# Altium schematic sheet ga-rele-c.SchDoc(ga-rele-c)
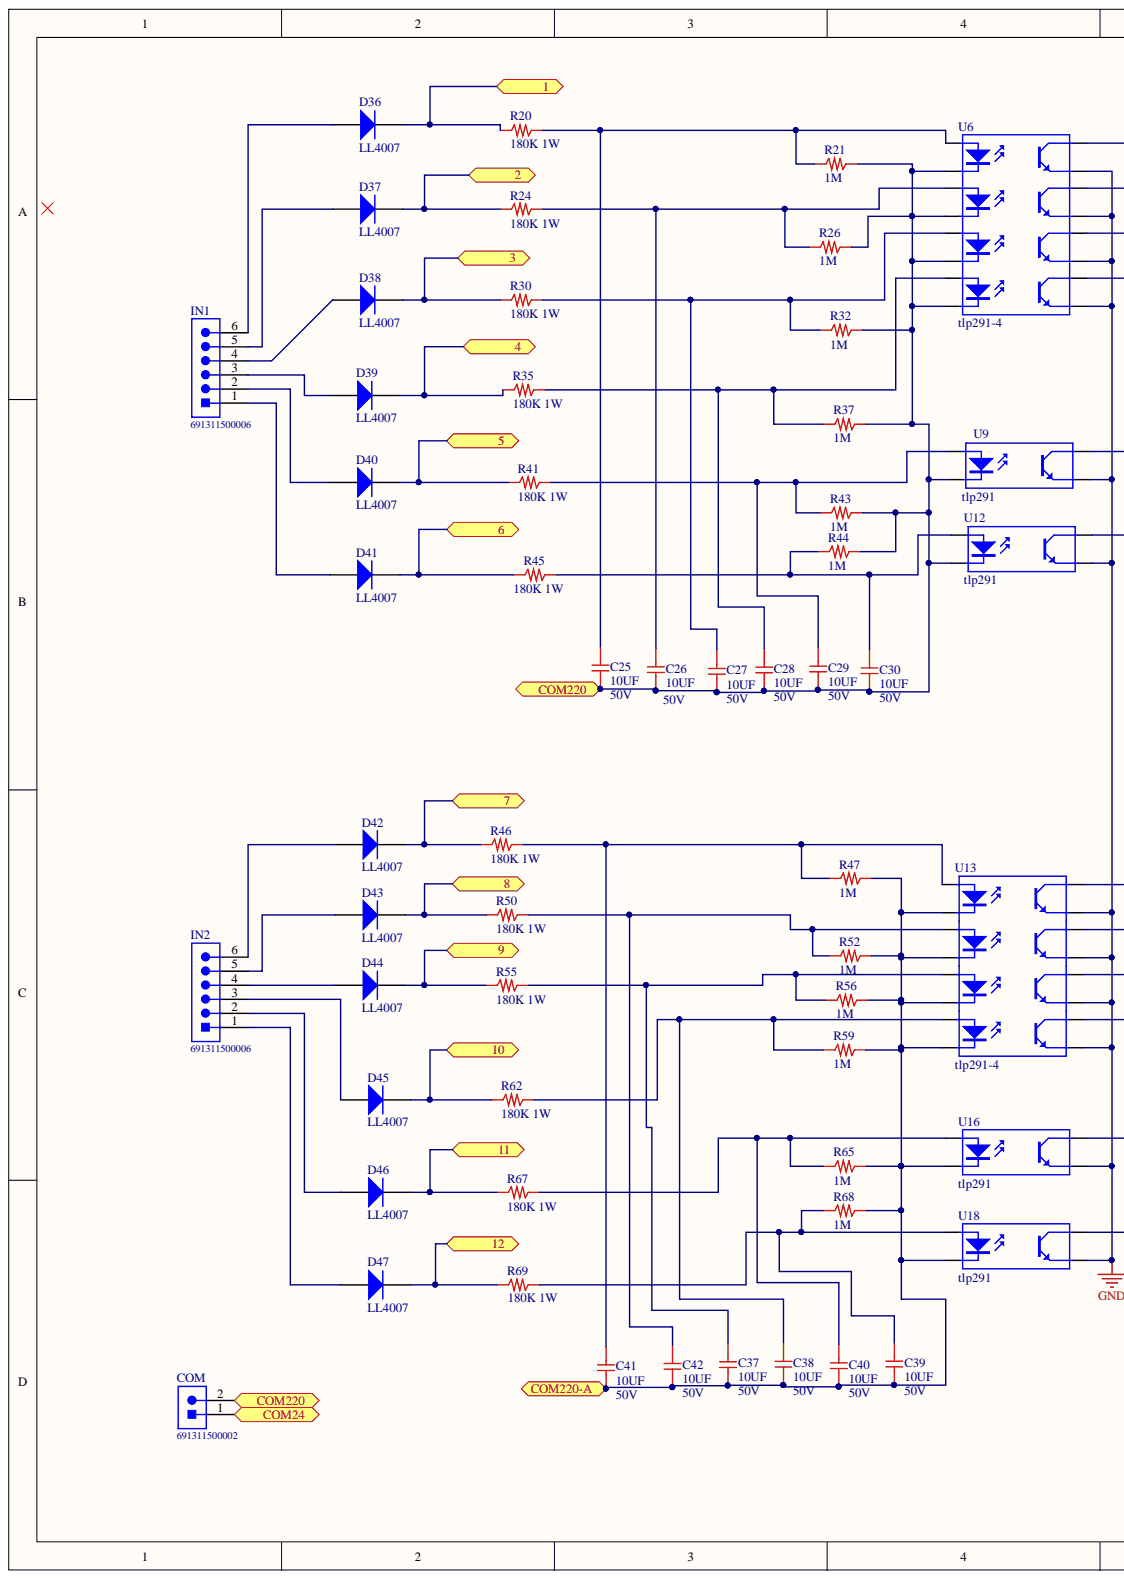
[diagram p0: ga-rele-c.SchDoc, left]
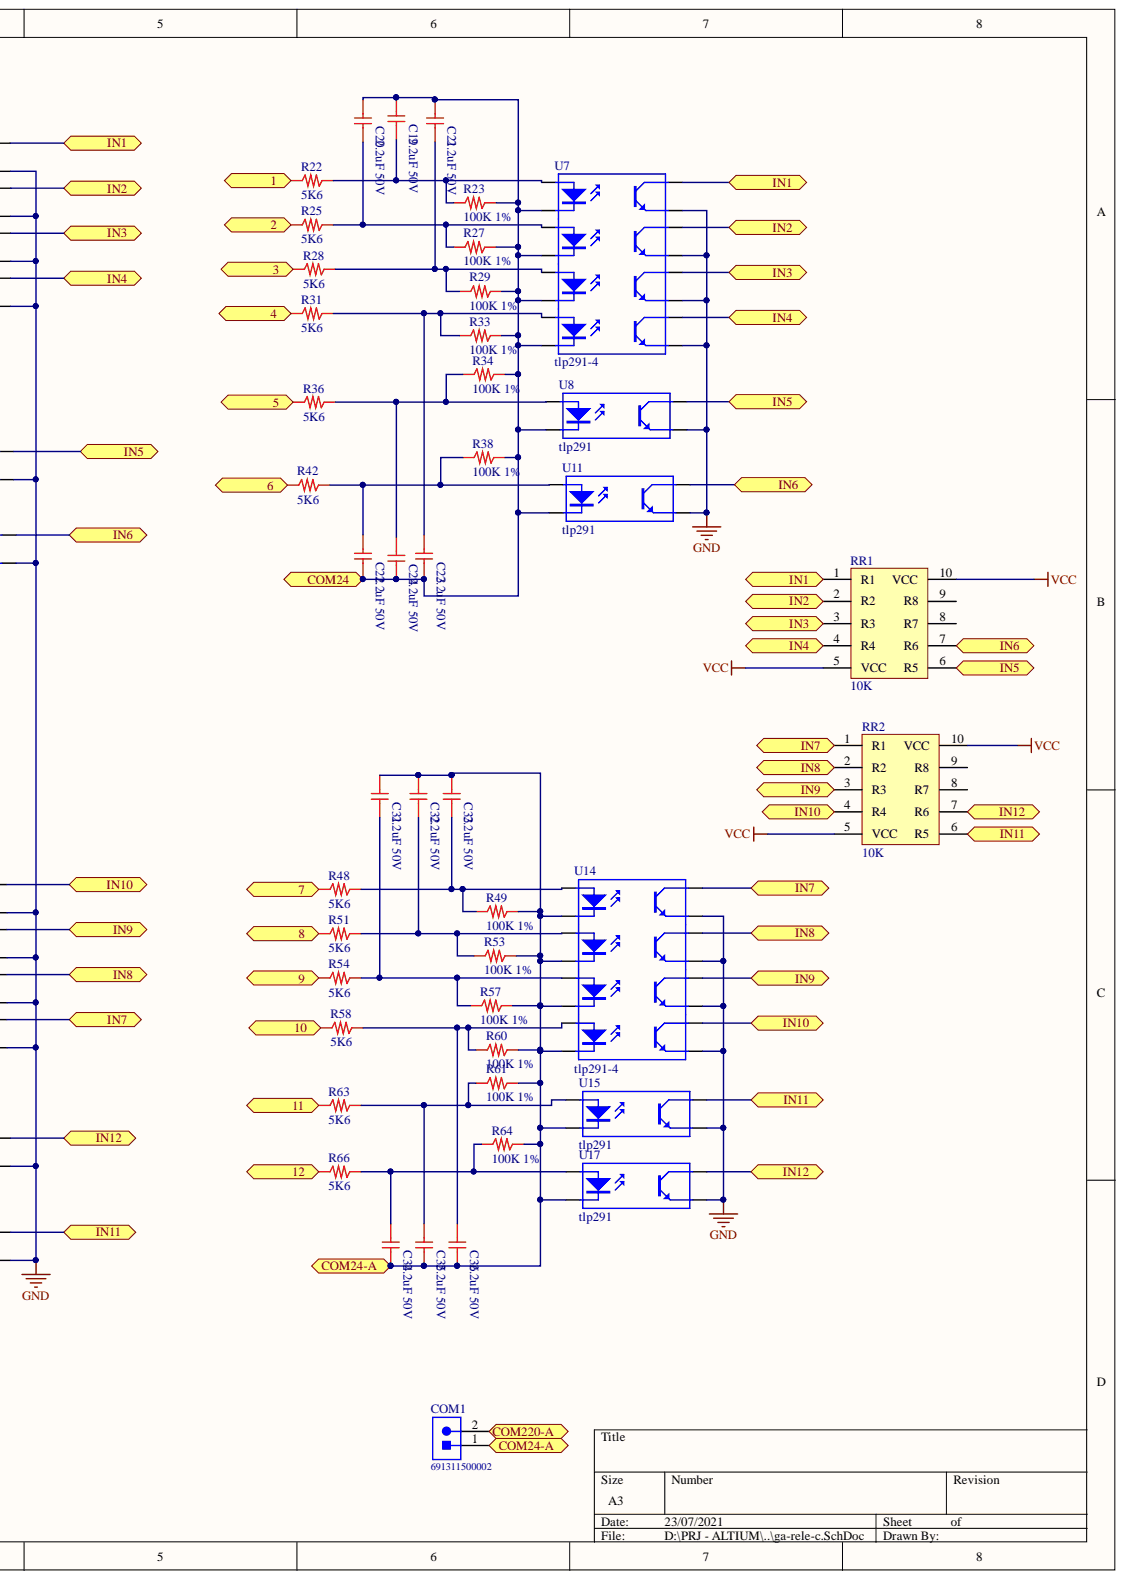
[diagram p1: ga-rele-c.SchDoc, right]

## Parts
C19  2.2uF 50V  [0805_C]
C20  2.2uF 50V  [0805_C]
C21  2.2uF 50V  [0805_C]
C22  2.2uF 50V  [0805_C]  (value not drawn)
C23  2.2uF 50V  [0805_C]
C24  2.2uF 50V  [0805_C]
C25  10UF  Tensione: 50V  [1206_C]
C26  10UF  Tensione: 50V  [1206_C]
C27  10UF  Tensione: 50V  [1206_C]
C28  10UF  Tensione: 50V  [1206_C]
C29  10UF  Tensione: 50V  [1206_C]
C30  10UF  Tensione: 50V  [1206_C]
C31  2.2uF 50V  [0805_C]
C32  2.2uF 50V  [0805_C]
C33  2.2uF 50V  [0805_C]
C34  2.2uF 50V  [0805_C]
C35  2.2uF 50V  [0805_C]
C36  2.2uF 50V  [0805_C]
C37  10UF  Tensione: 50V  [1206_C]
C38  10UF  Tensione: 50V  [1206_C]
C39  10UF  Tensione: 50V  [1206_C]
C40  10UF  Tensione: 50V  [1206_C]
C41  10UF  Tensione: 50V  [1206_C]
C42  10UF  Tensione: 50V  [1206_C]
COM  691311500002  [691311500002]
COM1  691311500002  [691311500002]
D36  LL4007  [LL4007]
D37  LL4007  [LL4007]
D38  LL4007  [LL4007]
D39  LL4007  [LL4007]
D40  LL4007  [LL4007]
D41  LL4007  [LL4007]
D42  LL4007  [LL4007]
D43  LL4007  [LL4007]
D44  LL4007  [LL4007]
D45  LL4007  [LL4007]
D46  LL4007  [LL4007]
D47  LL4007  [LL4007]
IN1  691311500006  [691311500006]
IN2  691311500006  [691311500006]
R20  180K 1W  [2512_R]
R21  1M  [1206_R]
R22  5K6  [1206_R]
R23  100K 1%  [0603_R]
R24  180K 1W  [2512_R]
R25  5K6  [1206_R]
R26  1M  [1206_R]
R27  100K 1%  [0603_R]
R28  5K6  [1206_R]
R29  100K 1%  [0603_R]
R30  180K 1W  [2512_R]
R31  5K6  [1206_R]
R32  1M  [1206_R]
R33  100K 1%  [0603_R]
R34  100K 1%  [0603_R]
R35  180K 1W  [2512_R]
R36  5K6  [1206_R]
R37  1M  [1206_R]
R38  100K 1%  [0603_R]
R41  180K 1W  [2512_R]
R42  5K6  [1206_R]
R43  1M  [1206_R]
R44  1M  [1206_R]
R45  180K 1W  [2512_R]
R46  180K 1W  [2512_R]
R47  1M  [1206_R]
R48  5K6  [1206_R]
R49  100K 1%  [0603_R]
R50  180K 1W  [2512_R]
R51  5K6  [1206_R]
R52  1M  [1206_R]
R53  100K 1%  [0603_R]
R54  5K6  [1206_R]
R55  180K 1W  [2512_R]
R56  1M  [1206_R]
R57  100K 1%  [0603_R]
R58  5K6  [1206_R]
R59  1M  [1206_R]
R60  100K 1%  [0603_R]
R61  100K 1%  [0603_R]
R62  180K 1W  [2512_R]
R63  5K6  [1206_R]
R64  100K 1%  [0603_R]
R65  1M  [1206_R]
R66  5K6  [1206_R]
R67  180K 1W  [2512_R]
R68  1M  [1206_R]
R69  180K 1W  [2512_R]
RR1  10K  [RR8+1-SMD]
RR2  10K  [RR8+1-SMD]
U6  tlp291-4  [SO16_NO]
U7  tlp291-4  [SO16_NO]
U8  tlp291  [SO4_N]
U9  tlp291  [SO4_N]
U11  tlp291  [SO4_N]
U12  tlp291  [SO4_N]
U13  tlp291-4  [SO16_NO]
U14  tlp291-4  [SO16_NO]
U15  tlp291  [SO4_N]
U16  tlp291  [SO4_N]
U17  tlp291  [SO4_N]
U18  tlp291  [SO4_N]

## Ports
1, 2, 3, 4, 5, 6, 7, 8, 9, 10, 11, 12, COM24, COM24-A, COM220, COM220-A, IN1, IN2, IN3, IN4, IN5, IN6, IN7, IN8, IN9, IN10, IN11, IN12

## Nets
GND: U6.9 U6.11 U6.13 U6.15 U7.9 U7.11 U7.13 U7.15 U8.3 U9.3 U11.3 U12.3 U13.9 U13.11 U13.13 U13.15 U14.9 U14.11 U14.13 U14.15 U15.3 U16.3 U17.3 U18.3
VCC: RR1.5(VCC) RR1.10(VCC) RR2.5(VCC) RR2.10(VCC)
1: D36.2 R20.1 R22.1 ; port 1
2: D37.2 R24.1 R25.1 ; port 2
3: D38.2 R28.1 R30.1 ; port 3
4: D39.2 R31.1 R35.1 ; port 4
5: D40.2 R36.1 R41.1 ; port 5
6: D41.2 R42.1 R45.1 ; port 6
7: D42.2 R46.1 R48.1 ; port 7
8: D43.2 R50.1 R51.1 ; port 8
9: D44.2 R54.1 R55.1 ; port 9
10: D45.2 R58.1 R62.1 ; port 10
11: D46.2 R63.1 R67.1 ; port 11
12: D47.2 R66.1 R69.1 ; port 12
COM24: C19.1 C20.1 C21.1 C22.2 C23.2 C24.2 COM.1 R23.2 R27.2 R29.2 R33.2 R34.2 R38.2 U7.2 U7.4 U7.6 U7.8 U8.2 U11.2 ; port COM24
COM24-A: C31.1 C32.1 C33.1 C34.2 C35.2 C36.2 COM1.1 R49.2 R53.2 R57.2 R60.2 R61.2 R64.2 U14.2 U14.4 U14.6 U14.8 U15.2 U17.2 ; port COM24-A
COM220: C25.1 C26.1 C27.1 C28.1 C29.1 C30.1 COM.2 R21.2 R26.2 R32.2 R37.2 R43.2 R44.2 U6.2 U6.4 U6.6 U6.8 U9.2 U12.2 ; port COM220
COM220-A: C37.1 C38.2 C39.1 C40.2 C41.2 C42.2 COM1.2 R47.2 R52.2 R56.2 R59.2 R65.2 R68.2 U13.2 U13.4 U13.6 U13.8 U16.2 U18.2 ; port COM220-A
IN1: RR1.1(R1) U6.16 U7.16 ; port IN1
IN2: RR1.2(R2) U6.14 U7.14 ; port IN2
IN3: RR1.3(R3) U6.12 U7.12 ; port IN3
IN4: RR1.4(R4) U6.10 U7.10 ; port IN4
IN5: RR1.6(R5) U8.4 U9.4 ; port IN5
IN6: RR1.7(R6) U11.4 U12.4 ; port IN6
IN7: RR2.1(R1) U13.10 U14.16 ; port IN7
IN8: RR2.2(R2) U13.12 U14.14 ; port IN8
IN9: RR2.3(R3) U13.14 U14.12 ; port IN9
IN10: RR2.4(R4) U13.16 U14.10 ; port IN10
IN11: RR2.6(R5) U15.4 U18.4 ; port IN11
IN12: RR2.7(R6) U16.4 U17.4 ; port IN12
NetC19_2: C19.2 R22.2 R23.1 U7.1
NetC20_2: C20.2 R25.2 R27.1 U7.3
NetC21_2: C21.2 R28.2 R29.1 U7.5
NetC22_1: C22.1 R38.1 R42.2 U11.1
NetC23_1: C23.1 R31.2 R33.1 U7.7
NetC24_1: C24.1 R34.1 R36.2 U8.1
NetC25_2: C25.2 R20.2 R21.1 U6.1
NetC26_2: C26.2 R24.2 R26.1 U6.3
NetC27_2: C27.2 R30.2 R32.1 U6.5
NetC28_2: C28.2 R35.2 R37.1 U6.7
NetC29_2: C29.2 R41.2 R43.1 U9.1
NetC30_2: C30.2 R44.1 R45.2 U12.1
NetC31_2: C31.2 R54.2 R57.1 U14.5
NetC32_2: C32.2 R51.2 R53.1 U14.3
NetC33_2: C33.2 R48.2 R49.1 U14.1
NetC34_1: C34.1 R64.1 R66.2 U17.1
NetC35_1: C35.1 R61.1 R63.2 U15.1
NetC36_1: C36.1 R58.2 R60.1 U14.7
NetC37_2: C37.2 R55.2 R56.1 U13.5
NetC38_1: C38.1 R59.1 R62.2 U13.7
NetC39_2: C39.2 R68.1 R69.2 U18.1
NetC40_1: C40.1 R65.1 R67.2 U16.1
NetC41_1: C41.1 R46.2 R47.1 U13.1
NetC42_1: C42.1 R50.2 R52.1 U13.3
NetD36_1: D36.1 IN1.6
NetD37_1: D37.1 IN1.5
NetD38_1: D38.1 IN1.4
NetD39_1: D39.1 IN1.3
NetD40_1: D40.1 IN1.2
NetD41_1: D41.1 IN1.1
NetD42_1: D42.1 IN2.6
NetD43_1: D43.1 IN2.5
NetD44_1: D44.1 IN2.4
NetD45_1: D45.1 IN2.3
NetD46_1: D46.1 IN2.2
NetD47_1: D47.1 IN2.1

Not connected: RR1 8(R7) 9(R8); RR2 8(R7) 9(R8)

## Library data of the parts
C19-C24 C31-C36 = 2.2uF 50V: Cap 0805 X7R 2.2uF 50V; Temperature Range: Da -55°C a +125°C; Library Name: CapSMD0805.SchLib; Component Kind: Standard
C25-C30 C37-C42 = 10UF 50V: Cap X5R 10UF 50V; Produttore: MURATA; Cod. Produttore: GRM31CR61H106KA12L; Temperature Range: Da -55°C a +125°C; Library Name: CapSMD1206.SchLib; Component Kind: Standard; Cod. Farnell: 2666583
COM COM1 = 691311500002: Serie 311 - 5.08mm Open Vertical PCB Header WR-TBL, 2 pin; Pin Number: 2; Gender: Male; Published Date: July 2018; Pitch: 5.08 mm; Part Number: 691311500002; Packaging: Box; Operating Temperature: -40 to 105 degC; Mounting Technology: THT; Library Name: Pluggable PCB System WR-TBL_5.08 mm.SchLib; ComponentLink2URL: http://katalog.we-online.de/em/datasheet/6913115000xx.pdf; ComponentLink2Description: Datasheet; ComponentLink1URL: http://www.we-online.de; ComponentLink1Description: Manufacturer; Component Kind: Standard; Publisher: Wurth Elektronik
D36-D47 = LL4007: High Conductance Fast Diode; Published: 8-Jun-2000; PackageReference: DO-35; PackageDescription: Diode, Axial; Body 3.8 x 1.9 mm (LxDia. max), Lead Dia. 0.53 mm (max); LatestRevisionNote: Re-released for DXP Platform.; LatestRevisionDate: 17-Jul-2002; DatasheetVersion: 1997; ComponentLink2URL: http://www.fairchildsemi.com/ds/1N%2F1N4148.pdf; ComponentLink2Description: Datasheet; ComponentLink1URL: http://www.fairchildsemi.com/; ComponentLink1Description: Manufacturer Link; Component Kind: Standard; Code_JEDEC: DO-204-AH; Publisher: Altium Limited; Library Name: HSW.SchLib
IN1 IN2 = 691311500006: Serie 311 - 5.08mm Open Vertical PCB Header WR-TBL, 6 pin; Pin Number: 6; Gender: Male; Published Date: July 2018; Pitch: 5.08 mm; Part Number: 691311500006; Packaging: Box; Operating Temperature: -40 to 105 degC; Mounting Technology: THT; Library Name: Pluggable PCB System WR-TBL_5.08 mm.SchLib; ComponentLink2URL: http://katalog.we-online.de/em/datasheet/6913115000xx.pdf; ComponentLink2Description: Datasheet; ComponentLink1URL: http://www.we-online.de; ComponentLink1Description: Manufacturer; Component Kind: Standard; Publisher: Wurth Elektronik
R20 R24 R30 R35 R41 R45 R46 R50 R55 R62 R67 R69 = 180K 5% 1W 500V_1: 180K 5% 1W 500V; Produttore: Vishay; Cod. Produttore: CRCW2512150KJNEG; Cod. Interno: RESS 4190510A0154; Library Name: ResSMD2512.SchLib; Component Kind: Standard; Cod. Farnell: 1886539
R21 R26 R32 R37 R43 R44 R47 R52 R56 R59 R65 R68 = 1M: 1M 1206 1/4W; Library Name: ResSMD1206.SchLib; Component Kind: Standard; Case: 1206
R22 R25 R28 R31 R36 R42 R48 R51 R54 R58 R63 R66 = R5K6: 5K6 1206 1/4W; Library Name: ResSMD1206.SchLib; Component Kind: Standard; Case: 1206
R23 R27 R29 R33 R34 R38 R49 R53 R57 R60 R61 R64 = 100K 1%: Res Film Spesso 1/16W 100K 1%; Produttore: ??; Cod. Interno: RESS4166360C0104; Library Name: ResSMD0603.SchLib; Component Kind: Standard; Cod. Produttore: ??
RR1 RR2 = RETE RESISTIVA-8+1: RETE RESISTIVA 10K 8+1 1206 746X101103JP -CTS; Component Kind: Standard; Library Name: HSW.SchLib
U6 U7 U13 U14 = tlp291_4: 4-Pin Phototransistor Optocoupler; Published: 24-Mar-1999; PackageReference: DIP-4; LatestRevisionNote: Sch pins 3 & 4 Swapped.; LatestRevisionDate: 04-Mar-2005; DatasheetVersion: Nov-2003; Component Kind: Standard; Publisher: Altium Limited; Library Name: HSW.SchLib
U8 U9 U11 U12 U15-U18 = tlp291: 4-Pin Phototransistor Optocoupler; Published: 24-Mar-1999; PackageReference: DIP-4; LatestRevisionNote: Sch pins 3 & 4 Swapped.; LatestRevisionDate: 04-Mar-2005; DatasheetVersion: Nov-2003; Component Kind: Standard; Publisher: Altium Limited; Library Name: HSW.SchLib
